# Altium schematic sheet OLED.SchDoc
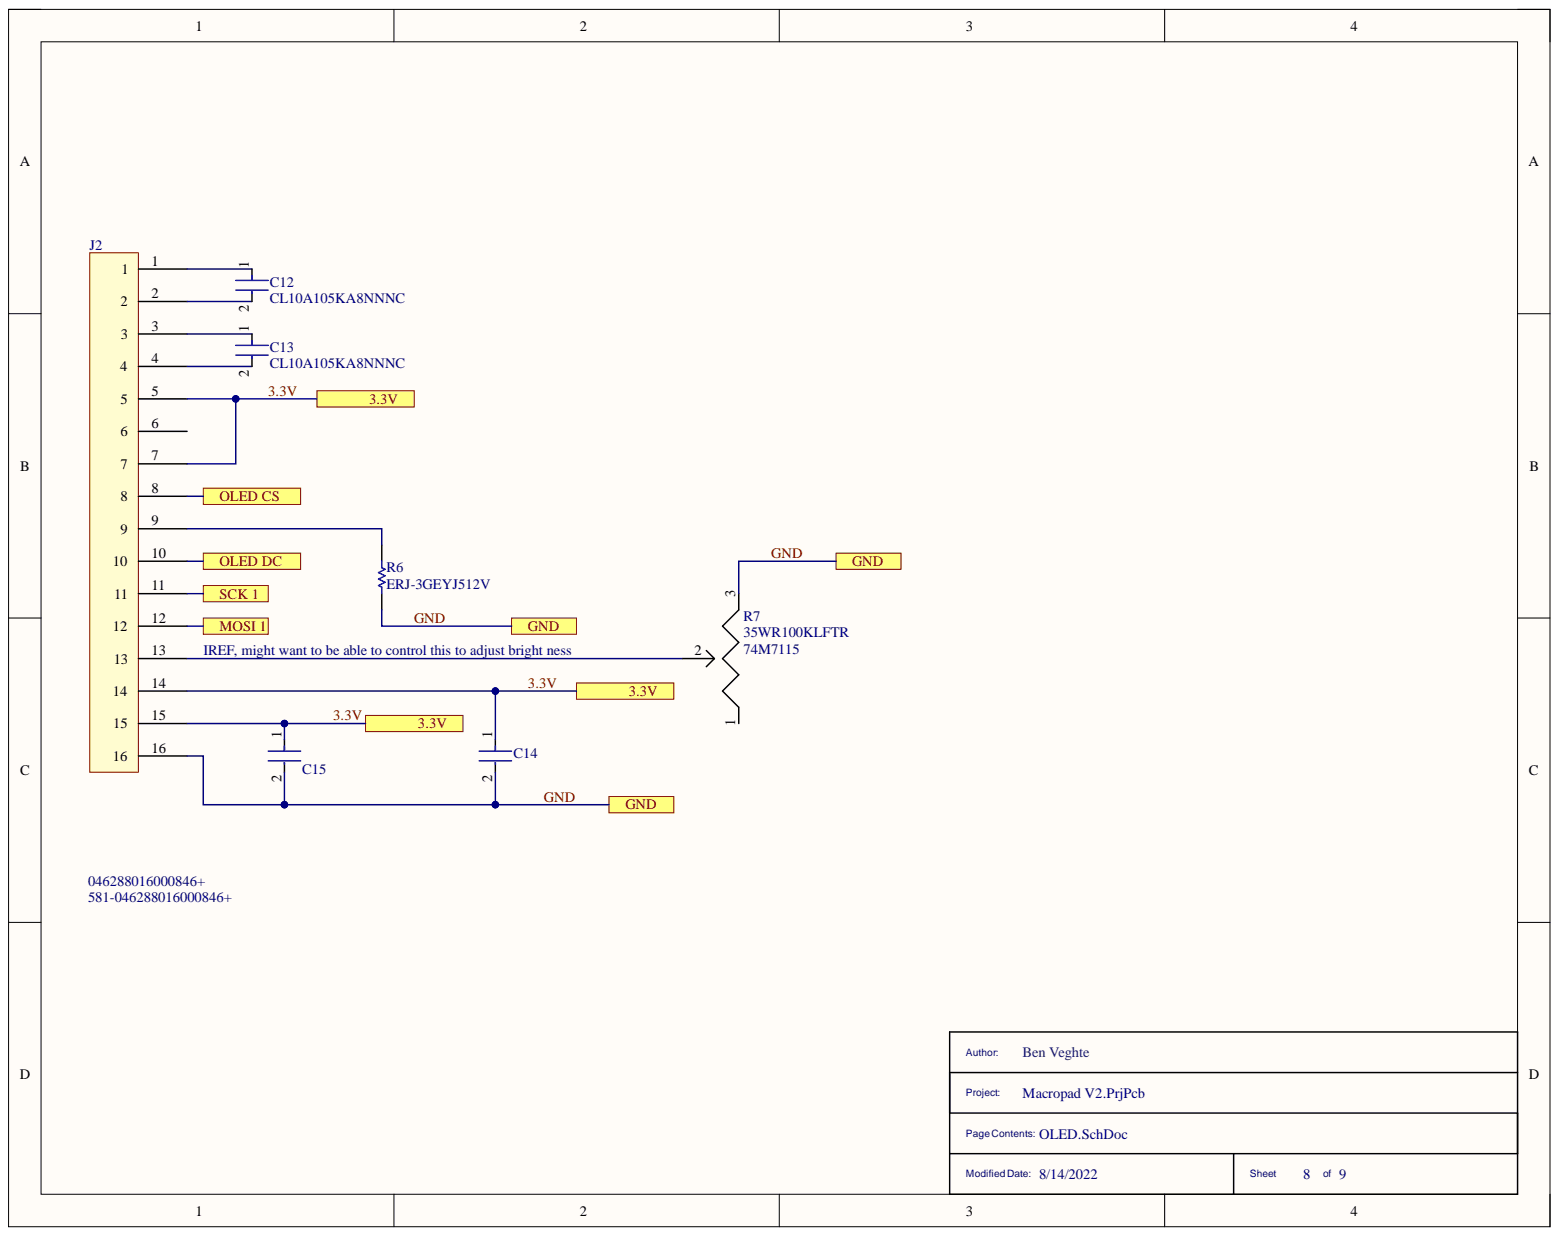
[diagram p0: OLED.SchDoc]

## Parts
C12  CL10A105KA8NNNC  [FP-CL10-IPC_C]
C13  CL10A105KA8NNNC  [FP-CL10-IPC_C]
C14  CL10A106KP8NNND  [FP-CL10-IPC_B]  (value not drawn)
C15  CL10A106KP8NNND  [FP-CL10-IPC_B]  (value not drawn)
J2  046288016000846+  [046288016000846+]
R6  ERJ-3GEYJ512V  [RESC1608X55X30LL15T15]
R7  35WR100KLFTR  Supplier Part Number 1: 74M7115  [35WR100KLFTR]

## Ports
3.3V, GND, MOSI 1, OLED CS, OLED DC, SCK 1

## Nets
3.3V: C14.1 C15.1 J2.5 J2.7 J2.14 J2.15 ; port 3.3V
GND: C14.2 C15.2 J2.16 R6.1 R7.3 ; port GND
MOSI 1: J2.12 ; port MOSI 1
OLED CS: J2.8 ; port OLED CS
OLED DC: J2.10 ; port OLED DC
SCK 1: J2.11 ; port SCK 1
NetC12_1: C12.1 J2.1
NetC12_2: C12.2 J2.2
NetC13_1: C13.1 J2.3
NetC13_2: C13.2 J2.4
NetJ2_9: J2.9 R6.2
NetJ2_13: J2.13 R7.2

Not connected: J2 6; R7 1

## Library data of the parts
C12 C13 = CMP-13271-004127-1: Cap Ceramic 1uF 25V X5R ±10% Pad SMD 0603 +85°C T/R; Capacitance: 1uF; Case Code (Imperial): 0603; Case Code (Metric): 1608; Case/Package: 0603; Component Kind: Standard; Contact Plating: Tin; Depth: 0.8mm; Dielectric: X5R; Height: 0.8mm; Lead Free: Lead Free; Length: 1.6mm; Library Name: Altium Content Vault; Material: Ceramic; Max Operating Temperature: 85°C; Min Operating Temperature: -55°C; Mount: Surface Mount; Package Quantity: 1; Packaging: Tape and Reel; Radiation Hardening: No; Thickness: 0.035inch; Tolerance: 10%; Voltage: 25V; Voltage Rating: 25V; Voltage Rating (DC): 25V; Width: 0.031inch
C14 C15 = CMP-13271-003245-1: Cap Ceramic 10uF 10V X5R ±10% Pad SMD 0603 +85°C T/R; Capacitance: 10uF; Case/Package: 0603; Component Kind: Standard; Depth: 0.8mm; Dielectric: X5R; Height: 0.8mm; Lead Free: Lead Free; Length: 1.6mm; Library Name: Altium Content Vault; Max Operating Temperature: 85°C; Min Operating Temperature: -55°C; Mount: Surface Mount; Package Quantity: 10000; Packaging: Tape and Reel; Radiation Hardening: No; Thickness: 0.035inch; Tolerance: 10%; Voltage Rating: 10V; Voltage Rating (DC): 10V; Width: 0.031inch
J2 = 046288016000846+: Conn FFC/FPC Connector SKT 16 POS 0.5mm Solder RA SMD Automotive T/R; Manufacturer: Kyocera AVX; Contact Location: Bottom; Current Rating: 400mA; Gender: Male; Number of Contacts: 16; Voltage Rating: 50V; Category: FFC / FPC; Component Kind: Standard; ComponentLink1Description: https://datasheet.ciiva.com/10366/6288-10366323.pdf?src-supplier=Avnet; ComponentLink1URL: https://datasheet.ciiva.com/10366/6288-10366323.pdf?src-supplier=Avnet; ComponentLink2Description: https://datasheet.ciiva.com/18454/70107521-18454565.pdf?src-supplier=Allied+Electronics; ComponentLink2URL: https://datasheet.ciiva.com/18454/70107521-18454565.pdf?src-supplier=Allied+Electronics; ComponentLink3Description: https://datasheet.ciiva.com/671/6288-671255.pdf?src-supplier=Digi-Key; ComponentLink3URL: https://datasheet.ciiva.com/671/6288-671255.pdf?src-supplier=Digi-Key; ComponentLink4Description: https://datasheet.ciiva.com/16327/6288-16327495.pdf?src-supplier=Future+Electronics; ComponentLink4URL: https://datasheet.ciiva.com/16327/6288-16327495.pdf?src-supplier=Future+Electronics; ComponentLink5Description: https://datasheet.ciiva.com/671/6288-671256.pdf?src-supplier=Digi-Key; ComponentLink5URL: https://datasheet.ciiva.com/671/6288-671256.pdf?src-supplier=Digi-Key; ComponentLink6Description: https://datasheet.ciiva.com/671/6288-671257.pdf?src-supplier=Digi-Key; ComponentLink6URL: https://datasheet.ciiva.com/671/6288-671257.pdf?src-supplier=Digi-Key; Contact Material: Copper Alloy; Contact Plating: Gold; Housing Material: Plastic; Lead Free: Lead Free; Manufacturer Part Number: 046288016000846+; Max Operating Temperature: 105°C; Min Operating Temperature: -40°C; Mount: Surface Mount; Number of Positions: 16; Number of Rows: 1; Orientation: Right Angle; Package Quantity: 1; Packaging: Tape and Reel; Pitch: 0.5mm; Pricing 1: 1=1.38000, 10=1.22000, 50=1.22000, 100=1.05000, 200=0.95100, 500=0.85600, 1000=0.76100, 2000=0.62700, 4000=0.61800, 10000=0.61800 (USD); Radiation Hardening: No; RoHS: Yes; Series: 6288; Stock 1: 2627; Supplier 1: Mouser; Supplier Part Number 1: 581-046288016000846+; Termination: Solder; Library Name: Universal Parts.IntLib
R6 = CMP-2000-00803-1: Manufacturer: Panasonic; Component Kind: Standard; Library Name: Altium Content Vault; Part Number: ERJ-3GEYJ512V
R7 = 35WR100KLFTR: 35W Series 3 mm Open Frame 100 kOhm ±25 % Single-Turn Trimming Potentiometer; Manufacturer: TT BI; Insulation Resistance: 100MR; Number of Turns: 1; Power Rating: 100mW; Resistance: 100kR; Resistance Tolerance: 25%; Temperature Coefficient: 250ppm/°C; Voltage Rating: 50V; Category: Variable Resistors and Potentiometers; Component Kind: Standard; ComponentLink1Description: https://datasheet.ciiva.com/22715/4701-22715101.pdf?src-supplier=Element14; ComponentLink1URL: https://datasheet.ciiva.com/22715/4701-22715101.pdf?src-supplier=Element14; ComponentLink2Description: https://datasheet.ciiva.com/7634/4701-7634922.pdf?src-supplier=Element14; ComponentLink2URL: https://datasheet.ciiva.com/7634/4701-7634922.pdf?src-supplier=Element14; Lead Free: Lead Free; Manufacturer Part Number: 35WR100KLFTR; Max Operating Temperature: 125°C; Min Operating Temperature: -40°C; Package Quantity: 2000; Packaging: Tape and Reel; Pricing 1: 1=0.35800, 10=0.34100, 25=0.32400, 50=0.30100, 100=0.28500, 250=0.27700, 500=0.24900, 1000=0.23800 (USD); REACH SVHC: No SVHC; Resistor Type: Cermet; RoHS: Yes; RoHS Compliant: Yes; Series: 37; Stock 1: 19940; Supplier 1: Newark; Termination: Solder; Tolerance: 25%; Type: Potentiometer; Voltage Rating (DC): 50V; Width: 1.55mm; Library Name: Universal Parts.IntLib
